# Altium schematic sheet Ports and Power.SchDoc("Ports and Power")
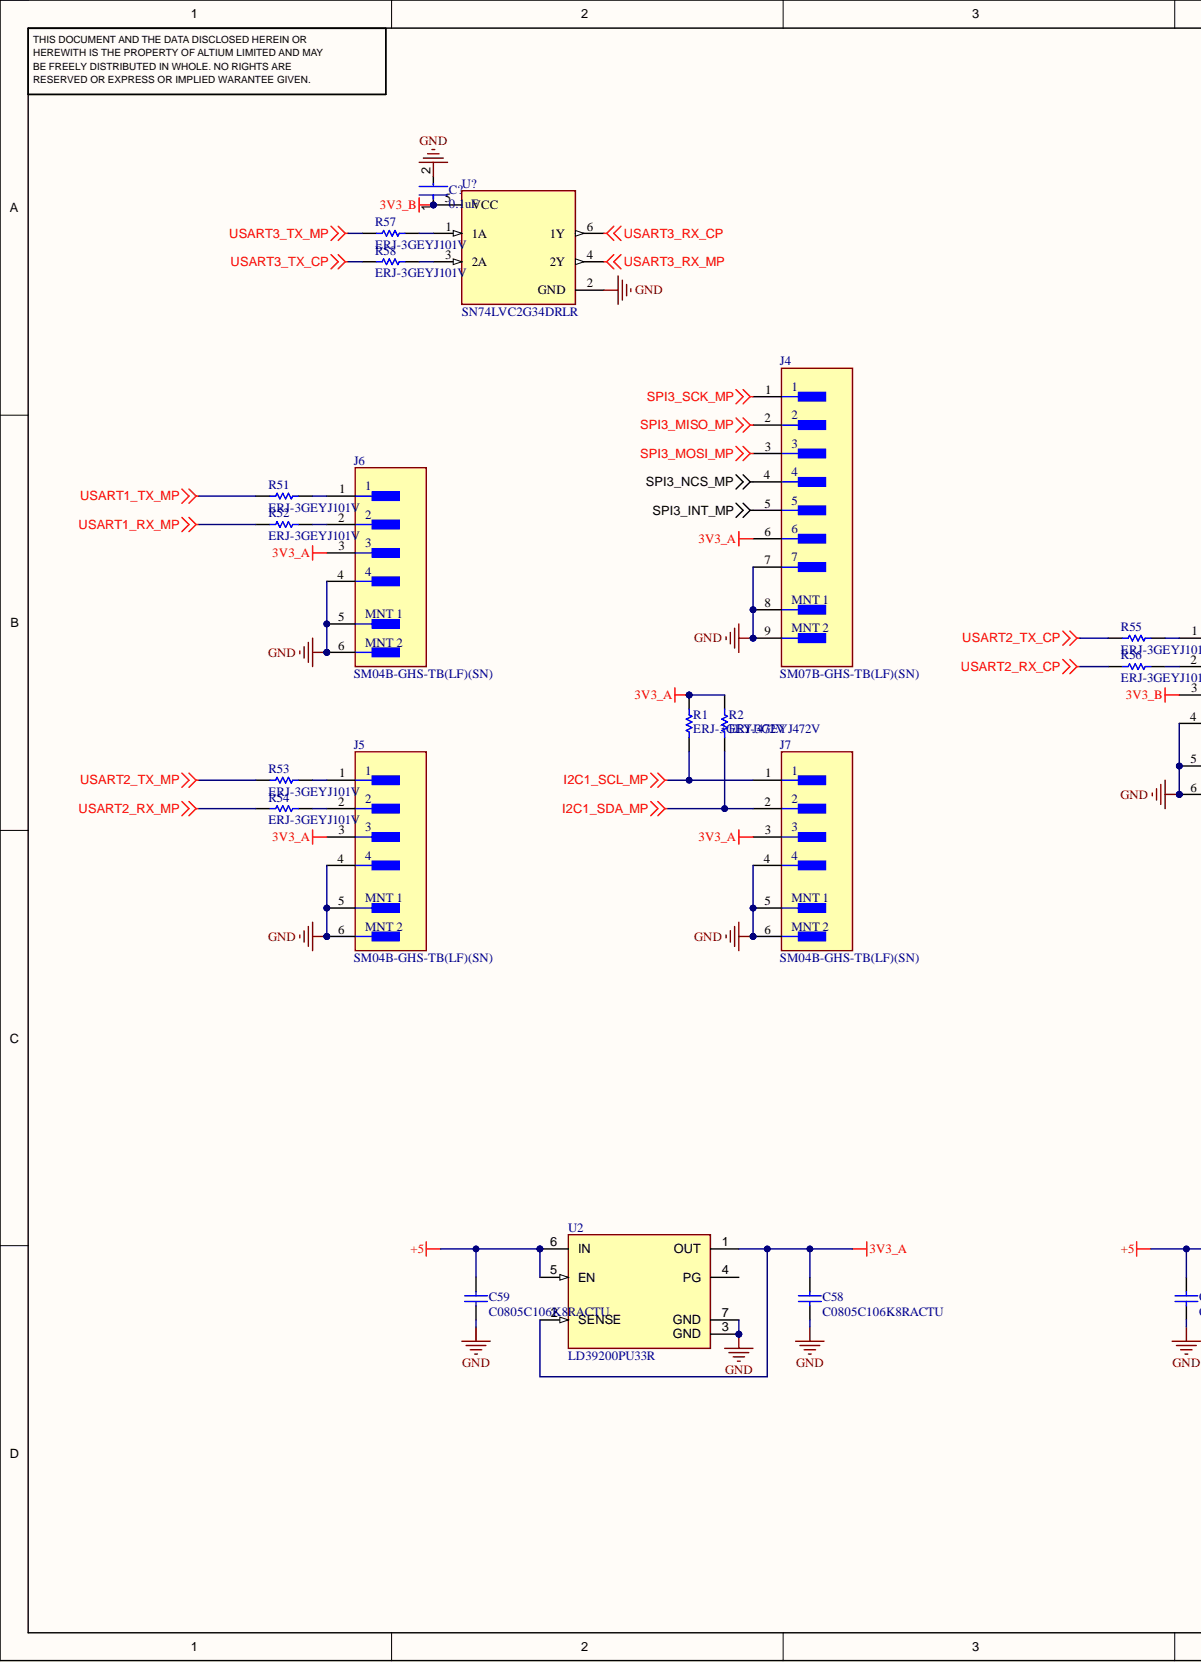
[diagram p0: Ports and Power.SchDoc, left]
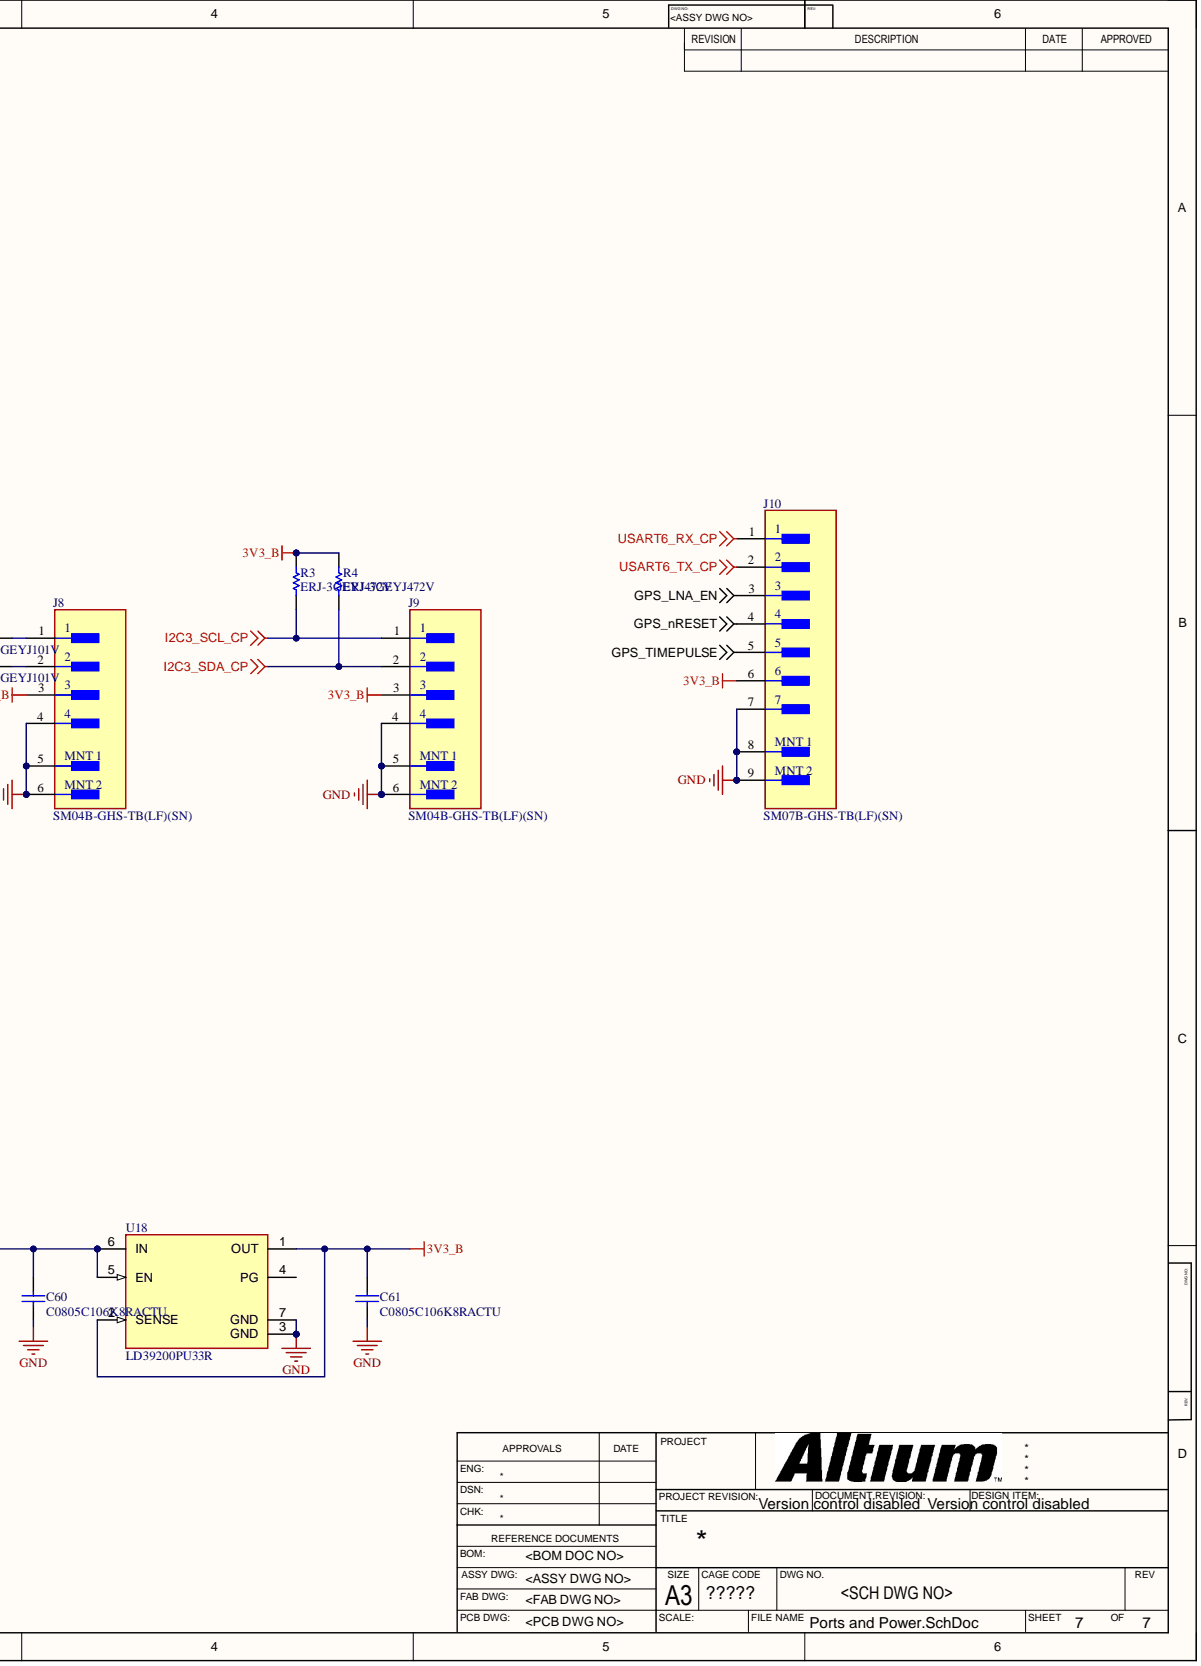
[diagram p1: Ports and Power.SchDoc, right]

## Parts
C58  C0805C106K8RACTU
C59  C0805C106K8RACTU
C60  C0805C106K8RACTU
C61  C0805C106K8RACTU
C?  0.1uF
J4  SM07B-GHS-TB(LF)(SN)
J5  SM04B-GHS-TB(LF)(SN)
J6  SM04B-GHS-TB(LF)(SN)
J7  SM04B-GHS-TB(LF)(SN)
J8  SM04B-GHS-TB(LF)(SN)
J9  SM04B-GHS-TB(LF)(SN)
J10  SM07B-GHS-TB(LF)(SN)
R1  ERJ-3GEYJ472V
R2  ERJ-3GEYJ472V
R3  ERJ-3GEYJ472V
R4  ERJ-3GEYJ472V
R51  ERJ-3GEYJ101V
R52  ERJ-3GEYJ101V
R53  ERJ-3GEYJ101V
R54  ERJ-3GEYJ101V
R55  ERJ-3GEYJ101V
R56  ERJ-3GEYJ101V
R57  ERJ-3GEYJ101V
R58  ERJ-3GEYJ101V
U2  LD39200PU33R
U18  LD39200PU33R
U?  SN74LVC2G34DRLR

## Nets
3V3_A: C58.1 J4.6 J5.3 J6.3 J7.3 R1.2 R2.1 U2.1(OUT) U2.2(SENSE)
3V3_B: C61.1 C?.1 J8.3 J9.3 J10.6 R3.2 R4.2 U18.1(OUT) U18.2(SENSE) U?.5(VCC)
+5: C59.1 C60.1 U2.5(EN) U2.6(IN) U18.5(EN) U18.6(IN)
GND: C58.2 C59.2 C60.2 C61.2 C?.2 J4.7 J4.8 J4.9 J5.4 J5.5 J5.6 J6.4 J6.5 J6.6 J7.4 J7.5 J7.6 J8.4 J8.5 J8.6 J9.4 J9.5 J9.6 J10.7 J10.8 J10.9 U2.3(GND) U2.7(GND) U18.3(GND) U18.7(GND) U?.2(GND)
GPS_LNA_EN: J10.3
GPS_nRESET: J10.4
GPS_TIMEPULSE: J10.5
I2C1_SCL_MP: J7.1 R1.1
I2C1_SDA_MP: J7.2 R2.2
I2C3_SCL_CP: J9.1 R3.1
I2C3_SDA_CP: J9.2 R4.1
SPI3_INT_MP: J4.5
SPI3_MISO_MP: J4.2
SPI3_MOSI_MP: J4.3
SPI3_NCS_MP: J4.4
SPI3_SCK_MP: J4.1
USART1_RX_MP: R52.1
USART1_TX_MP: R51.1
USART2_RX_CP: R56.1
USART2_RX_MP: R54.1
USART2_TX_CP: R55.1
USART2_TX_MP: R53.1
USART3_RX_CP: U?.6(1Y)
USART3_RX_MP: U?.4(2Y)
USART3_TX_CP: R58.1
USART3_TX_MP: R57.1
USART6_RX_CP: J10.1
USART6_TX_CP: J10.2
NetJ5_1: J5.1 R53.2
NetJ5_2: J5.2 R54.2
NetJ6_1: J6.1 R51.2
NetJ6_2: J6.2 R52.2
NetJ8_1: J8.1 R55.2
NetJ8_2: J8.2 R56.2
NetR57_2: R57.2 U?.1(1A)
NetR58_2: R58.2 U?.3(2A)

Not connected: U2 4(PG); U18 4(PG)

## Library data of the parts
C58-C61 = CMP-2007-01044-1: Manufacturer: Kemet; Component Kind: Standard; Library Name: Altium Content Vault; Part Number: C0805C106K8RACTU
C? = CMP-2006-03061-2: CAP CER 0.1UF 50V X7R 0603; Manufacturer: KEMET; Manufacturer Part Number: C0603C104K5RACTU; Automotive Grade: Grade 1; Capacitance: 0.10 μF; Material: Ceramic; Temperature Coefficient: 30ppm/°C; Tolerance: 0.1; Value: 0.10 μF; Automotive: Yes; Category: Cap; Component Kind: Standard; ComponentLink2Description: Datasheet; ComponentLink2URL: https://api.kemet.com/component-edge/download/datasheet/C0603C100J5GACAUTO.pdf; Device Class L1: Passive Components; Device Class L2: Capacitors; Device Class L3: Ceramic Capacitors; Digikey Description: CAP CER 0.1UF 50V X7R 0603; Height: 0.87mm; Lead Free: Yes; Library Name: Altium Content Vault; Octopart: https://octopart.com/c0603c104k5ractu-kemet-139244; Package: C0603C-CF; RoHS: TRUE; Temperature: -55°C to +125°C; Temperature Characteristic: X7R; Temperature Range High: +125°C; Temperature Range Low: -55°C; Voltage Rating: 50 V
J4 J10 = CMP-17439-000109-1: CONN HEADER SMD R/A 7POS 1.25MM; Current Rating: 1A; Number of Contacts: 7; Pitch: 0.049inch; Voltage Rating: 50V; Wire/Cable Gauge: 26AWG; Color: Natural; Component Kind: Standard; Connector Type: Header; Contact Finish - Mating: TIN; Contact Material: Bronze; Contact Plating: Tin; Contact Shape: Rectangular; Contact Type: Outer Shroud Contact; Fastening Type: Ramp; Flammability Rating: UL94 V-0; Housing Color: Natural; Housing Material: Nylon; Insulation Color: Natural; Insulation Material: Nylon; Lead Free: Lead Free; Library Name: Altium Content Vault; Max Operating Temperature: 85°C; Min Operating Temperature: -25°C; Mount: Surface Mount; Number of Positions: 7; Number of Rows: 1; Package Quantity: 2500; Packaging: Cut Tape; Series: GH; Termination: Solder; Voltage Rating (AC): 50V
J5-J9 = CMP-17439-000411-1: CONN HEADER SMD R/A 4POS 1.25MM; Current Rating: 1A; Number of Contacts: 4; Voltage Rating: 50V; Component Kind: Standard; Contact Material: Bronze; Contact Plating: Tin; Housing Color: Natural; Housing Material: Nylon; Lead Free: Lead Free; Library Name: Altium Content Vault; Mount: Surface Mount; Number of Positions: 4; Number of Rows: 1; Orientation: Right Angle; Packaging: Cut Tape; Pitch: 1.25mm; REACH SVHC: No SVHC; Series: GH; Termination: Solder
R1-R4 = CMP-2000-00796-1: Manufacturer: Panasonic; Component Kind: Standard; Library Name: Altium Content Vault; Part Number: ERJ-3GEYJ472V
R51-R58 = CMP-2000-00702-1: Manufacturer: Panasonic; Component Kind: Standard; Library Name: Altium Content Vault; Part Number: ERJ-3GEYJ101V
U2 U18 = CMP-12153-000011-1: IC REG LINEAR 3.3V 2A 6DFN; Dropout Voltage: 130mV; Max Input Voltage: 6V; Min Input Voltage: 1.25V; Number of Outputs: 1; Operating Supply Current: 1mA; Output Type: Fixed; Case/Package: DFN; Component Kind: Standard; Lead Free: Lead Free; Library Name: Altium Content Vault; Max Operating Temperature: 125°C; Min Operating Temperature: -40°C; Mount: Surface Mount; Number of Regulators: 1; Output Current: 2A; Output Voltage: 3.3V; Package Quantity: 3000; Packaging: Tape and Reel
U? = CMP-0859-00812-4: IC BUFFER NON-INVERT 5.5V 6SOT; High Level Output Current: -32mA; Low Level Output Current: 32mA; Min Supply Voltage: 1.65V; Number of Bits: 2; Number of Bits per Element: 1; Polarity: Non-Inverting; Propagation Delay: 8.6ns; Quiescent Current: 10uA; Case/Package: SOT; Component Kind: Standard; Contact Plating: Gold; Lead Free: Lead Free; Library Name: Altium Content Vault; Logic Function: Buffer; Max Operating Temperature: 125°C; Max Supply Voltage: 5.5V; Min Operating Temperature: -40°C; Mount: Surface Mount; Number of Channels: 2; Number of Elements: 2; Number of Gates: 2; Number of Input Lines: 2; Number of Inputs: 2; Number of Output Lines: 2; Number of Outputs: 2; Number of Pins: 6; Package Quantity: 4000; Packaging: Tape and Reel; Radiation Hardening: No; REACH SVHC: No SVHC; RoHS Compliant: Yes; Series: 74LVC; Technology: CMOS; Turn-On Delay Time: 8.6ns
